# Altium schematic sheet laser_power_sw.SchDoc (continued)

## Library data of the parts
C28 C47 C49 C68 = Capacitor_3: 2kV, X7R, 10%; Manufacturer: YAGEO; Manufacturer_Part_Number: CC1206KKX7RDBB102; Component Kind: Standard; Library Name: HiPin_Library_AD.IntLib
C29 C30 C32 C33 C41 C42 C44 C45 C50 C51 C55 C56 C62 C63 C65 C66 C81 = Capacitor_3: 50V, X7R, 20%; Internal_Part_Number: MCD-00008; Manufacturer: muRata; Manufacturer_Part_Number: GRM32ER71H106KA12L; Component Kind: Standard; Library Name: HiPin_Library_AD.IntLib; Value: 10uF
C31 C43 C46 C52 C64 C67 = Capacitor_2: 50V, X7R , 10%; Internal_Part_Number: MCD-00014; Manufacturer: muRata; Manufacturer_Part_Number: GRM188R71H103KA01D; Component Kind: Standard; ComponentLink1Description: Datasheet; ComponentLink1URL: http://tunmcdsvn.tun.st.com/Component_Datasheet_Library/Trunk/Capacitors/Yageo_Multilayer_capacitor_X5R_series_100pF_to_100uF; Library Name: HiPin_Library_AD.IntLib; Value: 10nF
C34 C35 = 10uF: 35V,15Ω@100kHz; BOM_Manufacturer: AVX; BOM_Manufacturer Part: TAJA334K035RNJ; nameAlias: Capacitance; Component Kind: Standard; Library Name: HiPin_Library_AD.IntLib
C36 C38 C57 C59 = HV1V337M1010PZ: 330uF, 50V, 20%; Manufacturer: HONOR(荣誉); Add into BOM: yes; L: 10mm; Rated Voltage: 35V; Component Kind: Standard; Convert to PCB: yes; Datasheet: https://atta.szlcsc.com/upload/public/pdf/source/20240802/61683F5000C7CFED5606ABBE3CFBE0F5.pdf; Device: HV1V337M1010PZ; JLCPCB Part Class: Extended Part; LCSC Part Name: 330uF ±20% 35V; Library Name: HiPin_Library_AD.IntLib; Manufacturer Part: HV1V337M1010PZ; Operating Temperature: -55℃~+105℃; Supplier: LCSC; Supplier Footprint: SMD,D10xL10mm; Supplier Part: C5246558; Symbol: HV1V337M1010PZ; Tolerance: ±20%; φD: 10mm
C37 C39 C40 C58 C60 C61 = Capacitor_3: 50V, X7R, 10%; Manufacturer: muRata; Manufacturer_Part_Number: GRM31CR61H106KA12L; Component Kind: Standard; Library Name: HiPin_Library_AD.IntLib; Value: 10uF
C48 C69 C78 = Capacitor_2: 50V, X5R, 10%; Internal_Part_Number: MCD-00014; Manufacturer: muRata; Manufacturer_Part_Number: GRM21BR61E226ME44L; Value: 22uF; Component Kind: Standard; ComponentLink1Description: Datasheet; ComponentLink1URL: http://tunmcdsvn.tun.st.com/Component_Datasheet_Library/Trunk/Capacitors/Yageo_Multilayer_capacitor_X5R_series_100pF_to_100uF; Library Name: HiPin_Library_AD.IntLib
C53 = Capacitor_1: 25V, X7R, 10%; Manufacturer: muRata; Manufacturer_Part_Number: GCM188R71E105KA64D; Component Kind: Standard; ComponentLink1Description: Yageo_Capacitor_X5R-series.pdf; ComponentLink1URL: http://tunmcdsvn.tun.st.com/Component_Datasheet_Library/Trunk/Capacitors/Yageo_Multilayer_capacitor_X5R_series_100pF_to_100uF; Library Name: HiPin_Library_AD.IntLib; Package: C0603
C54 = 10uF: TA 0.8ohm; Manufacturer: AVX; Manufacturer Part: TAJA475K016RNJ; Component Kind: Standard; Library Name: HiPin_Library_AD.IntLib
C70 = 10uF: TA 0.8ohm; BOM_Manufacturer: AVX; BOM_Manufacturer Part: TAJA334K035RNJTAJA334K035RNJ; nameAlias: Capacitance; BOM_JLCPCB Part Class: Basic Part; BOM_Supplier: LCSC; BOM_Supplier Part: C7171; Component Kind: Standard; Library Name: HiPin_Library_AD.IntLib; spicePre: C; spiceSymbolName: TAJA106K016RNJ
C71 = 100uF: 6.3mm*7.7mm,16V; BOM_Manufacturer: HONOR(荣誉); BOM_Manufacturer Part: GVE1C471M0607; Contributor: LCSC; nameAlias: Capacitance; BOM_JLCPCB Part Class: Extended Part; Component Kind: Standard; Library Name: HiPin_Library_AD.IntLib; spicePre: C; spiceSymbolName: UWT1V101MCL1GS
C72 = TAJD107M020RNJ: ±20%,额定电压20V, 500mΩ@100kHz; Manufacturer: Kyocera AVX; Add into BOM: yes; Equivalent Series Resistance(ESR): 500m��@100kHz; Rated Voltage: 20V; Tolerance: ��20%; Value: 100uF; Component Kind: Standard; Convert to PCB: yes; Datasheet: https://atta.szlcsc.com/upload/public/pdf/source/20180326/C99373_20A9870CE26650D026AD36BBE6313154.pdf; Device: TAJD107M020RNJ; JLCPCB Part Class: Extended Part; LCSC Part Name: 100uF ��20% 20V; Library Name: HiPin_Library_AD.IntLib; Manufacturer Part: TAJD107M020RNJ; Operating Temperature: -55��~+125��; Supplier: LCSC; Supplier Footprint: CASE-D_7343; Supplier Part: C99373; Symbol: TAJD107M020RNJ
C73 = Capacitor_1: 16V, X5R, 10%; Internal_Part_Number: MCD-00009; Manufacturer: muRata; Component Kind: Standard; ComponentLink1Description: Yageo_Capacitor_X5R-series.pdf; ComponentLink1URL: http://tunmcdsvn.tun.st.com/Component_Datasheet_Library/Trunk/Capacitors/Yageo_Multilayer_capacitor_X5R_series_100pF_to_100uF; Library Name: HiPin_Library_AD.IntLib; Package: C0603
C74 C77 C87 C92 C99 = Capacitor_1: 16V, X5R, 10%; Internal_Part_Number: MCD-00009; Manufacturer: muRata; Manufacturer_Part_Number: GRM188R61E105KA12D; Component Kind: Standard; ComponentLink1Description: Yageo_Capacitor_X5R-series.pdf; ComponentLink1URL: http://tunmcdsvn.tun.st.com/Component_Datasheet_Library/Trunk/Capacitors/Yageo_Multilayer_capacitor_X5R_series_100pF_to_100uF; Library Name: HiPin_Library_AD.IntLib; Package: C0603; Value: 1uF
C75 C76 = Capacitor: 50V, 10%, X7R; Internal_Part_Number: MCD-00003; Manufacturer: muRata; Manufacturer_Part_Number: GRM155R71H104KE14D; Component Kind: Standard; ComponentLink1Description: Datasheet; ComponentLink1URL: http://tunmcdsvn.tun.st.com/Component_Datasheet_Library/Trunk/Capacitors/Yageo_Capacitor_NP0_16V-to-50V; Library Name: HiPin_Library_AD.IntLib; Package: C0402
  C75: Value: 100nF
C79 = C352S475K9SC000: CBB电容，22.5mm; Manufacturer: faratronic(厦门法拉); Add into BOM: yes; Tolerance: ±10%; Voltage Rated: 450V; Component Kind: Standard; Convert to PCB: yes; Datasheet: https://atta.szlcsc.com/upload/public/pdf/source/20220818/525217545BC089B5EDAE0BB9513219DD.pdf; Device: C352S475K9SC000; JLCPCB Part Class: Extended Part; LCSC Part Name: 4.7uF ±10% 450V; Library Name: HiPin_Library_AD.IntLib; Manufacturer Part: C352S475K9SC000; PCB3D: CAP-TH_L26.5-W11.0-H23.0-P22.5; Supplier: LCSC; Supplier Footprint: 插件,P=22.5mm; Supplier Part: C4944910; Symbol: C352S475K9SC000; Value: 4.7uF
C80 C83 C96-C98 C107 = Capacitor_3: 25V, X7R, 10%; Internal_Part_Number: MCD-00008; Manufacturer: muRata; Manufacturer_Part_Number: GRM31CR71E475KA88L; Component Kind: Standard; Library Name: HiPin_Library_AD.IntLib
C82 = Capacitor_3: 50V, C0G, 1%; Internal_Part_Number: MCD-00008; Manufacturer: muRata; Manufacturer_Part_Number: GRM31C5C1H104FA01L; Component Kind: Standard; Library Name: HiPin_Library_AD.IntLib
C84-C86 C90 C91 C100 C101 C103-C106 = Capacitor_1: 50V, X7R, 10%; Manufacturer: muRata; Manufacturer_Part_Number: GRM188R71H104KA93D; Component Kind: Standard; ComponentLink1Description: Yageo_Capacitor_X5R-series.pdf; ComponentLink1URL: http://tunmcdsvn.tun.st.com/Component_Datasheet_Library/Trunk/Capacitors/Yageo_Multilayer_capacitor_X5R_series_100pF_to_100uF; Library Name: HiPin_Library_AD.IntLib; Package: C0603
C88 = Capacitor_2: 50V, X5R, 10%; Internal_Part_Number: MCD-00014; Manufacturer: muRata; Manufacturer_Part_Number: GRM21BR61E226ME44L; Component Kind: Standard; ComponentLink1Description: Datasheet; ComponentLink1URL: http://tunmcdsvn.tun.st.com/Component_Datasheet_Library/Trunk/Capacitors/Yageo_Multilayer_capacitor_X5R_series_100pF_to_100uF; Library Name: HiPin_Library_AD.IntLib
C89 C102 = Capacitor_1: 50V,C0G, 5%; Manufacturer: muRata; Manufacturer_Part_Number: GRM1885C1H101JA01D; Component Kind: Standard; ComponentLink1Description: Yageo_Capacitor_X5R-series.pdf; ComponentLink1URL: http://tunmcdsvn.tun.st.com/Component_Datasheet_Library/Trunk/Capacitors/Yageo_Multilayer_capacitor_X5R_series_100pF_to_100uF; Library Name: HiPin_Library_AD.IntLib; Package: C0603
C93 = 10uF: TA 0.8ohm; BOM_Manufacturer: AVX; BOM_Manufacturer Part: TAJA106K016RNJ; nameAlias: Capacitance; BOM_JLCPCB Part Class: Basic Part; BOM_Supplier: LCSC; BOM_Supplier Part: C7171; Component Kind: Standard; Library Name: HiPin_Library_AD.IntLib; spicePre: C; spiceSymbolName: TAJA106K016RNJ
C94 C95 = Capacitor_1: 50V,C0G, 5%; Manufacturer: muRata; Manufacturer_Part_Number: GCM1885C1H221JA16D; Component Kind: Standard; ComponentLink1Description: Yageo_Capacitor_X5R-series.pdf; ComponentLink1URL: http://tunmcdsvn.tun.st.com/Component_Datasheet_Library/Trunk/Capacitors/Yageo_Multilayer_capacitor_X5R_series_100pF_to_100uF; Library Name: HiPin_Library_AD.IntLib; Package: C0603
CN1 CN2 = BUFU-1650-01: Number of Leg: 16; Screw Hole Size: M5; Component Kind: Standard; LCSC Part Name: PCB焊接端子抽牙M6 六脚 14.5*14.5*15mm T1.5 镀雾锡; Library Name: HiPin_Library_AD.IntLib; PCB3D: TH_6P-L14.5-W14.5-P6.25; Supplier Footprint: 插件; Supplier Part: C19724526
D4 = SS34_C8678: BOM_Manufacturer: MDD; BOM_JLCPCB Part Class: Basic Part; BOM_Manufacturer Part: SS34; BOM_Supplier: LCSC; BOM_Supplier Part: C8678; Component Kind: Standard; Library Name: HiPin_Library_AD.IntLib; spicePre: D; spiceSymbolName: SS34_C8678
D5 = DFLS160-7: Manufacturer: DIODES(美台); Add into BOM: yes; Forward Voltage (Vf@If): 500mV@1A; Rectified Current: 1A; Reverse Voltage (Vr): 60V; Component Kind: Standard; Convert to PCB: yes; Datasheet: https://atta.szlcsc.com/upload/public/pdf/source/20181114/C177023_80F0A2460033305602CFDDCB3101FB4E.pdf; Device: DFLS160-7; JLCPCB Part Class: Extended Part; LCSC Part Name: 电压:60V 电流:1A; Library Name: HiPin_Library_AD.IntLib; Manufacturer Part: DFLS160-7; PCB3D: POWERDI-123_L2.8-W1.8-H1.0-LS3.7; Supplier: LCSC; Supplier Footprint: Power-DI-123; Supplier Part: C177023; Symbol: DFLS160-7
D6 = SMBJ18A/TR13: Manufacturer: Brightking(��ҫ����); Add into BOM: yes; Breakdown Voltage: 20V; Maximum Clamping Voltage: 29.2V; Peak Pulse Current (Ipp)@10/1000us: 20.6A; Peak Pulse Power Dissipation (Ppp)@10/1000us: 600W; polarity: ����; Reverse Leakage Current (Ir): 1uA; Reverse Stand-Off Voltage (Vrwm): 18V; Symbol: SMBJ18A-C78412; Type: TVS; Component Kind: Standard; Convert to PCB: yes; Datasheet: https://atta.szlcsc.com/upload/public/pdf/source/20181127/C309995_EB3DFB9F9AEE5D2146ECD9FA5992A402.pdf; Device: SMBJ18A/TR13; JLCPCB Part Class: Extended Part; LCSC Part Name: SMBJ18A/TR13; Library Name: HiPin_Library_AD.IntLib; Manufacturer Part: SMBJ18A/TR13; Supplier: LCSC; Supplier Footprint: SMB(DO-214AA); Supplier Part: C78412
D7 = 1N4148W-C84367: BOM_Manufacturer: MDD; BOM_JLCPCB Part Class: Extended Part; BOM_Manufacturer Part: 1N4148W; BOM_Supplier: LCSC; BOM_Supplier Part: C84367; Component Kind: Standard; Library Name: HiPin_Library_AD.IntLib; spicePre: D; spiceSymbolName: 1N4148W-C84367
D8 = SMAJ6.8CA_C406209: 极性  双向  反向截止电压  18V  钳位电压  29.2V  峰值脉冲电流  13.7A@10/1000; Manufacturer: HJC; Add into BOM: yes; Reverse Stand-Off Voltage (Vrwm): 6.8V; Type: TVS; Component Kind: Standard; Convert to PCB: yes; Library Name: HiPin_Library_AD.IntLib; Manufacturer Part: SMAJ18CA; Supplier Footprint: SMA(DO-214AC)
F2 F3 = RES: 耐压: 30V  最大电流: 40A  保持电流(Ih): 500mA  跳闸电流: 1A; Value: 10K; Component Kind: Standard; Library Name: HiPin_Library_AD.IntLib
FB1 FB2 = BLM31PG601SN1L: Manufacturer: Sunlord(顺络); Add into BOM: yes; Circuits: 1; Current Rating: 1.5A; DC Resistance: 80mΩ; Impedance @ Frequency: 600Ω@100MHz; Tolerance: ±25%; Component Kind: Standard; Convert to PCB: yes; Datasheet: https://atta.szlcsc.com/upload/public/pdf/source/20180326/C88997_FE7208E239BA92CF7577D85CF2703D30.pdf; Device: BLM31PG601SN1L; JLCPCB Part Class: Extended Part; LCSC Part Name: 磁珠 阻抗38Ω@100MHz 5A; Library Name: HiPin_Library_AD.IntLib; Manufacturer Part: PZ3216D380-5R0TF; Supplier: LCSC; Supplier Footprint: 1206; Supplier Part: C16902; Symbol: BLM31PG601SN1L
L3 L4 = XRUU9.8-1.5mH-V: Manufacturer: XR(祥如); Add into BOM: yes; Inductance @ Frequency: 1.5mH@1kHz; Number of Lines: 2; Component Kind: Standard; Convert to PCB: yes; Datasheet: https://atta.szlcsc.com/upload/public/pdf/source/20240926/D8705A132F62F7D546575D85F6052ABD.pdf; Device: XRUU9.8-1.5mH-V; JLCPCB Part Class: Extended Part; LCSC Part Name: UU9.8 1.5mH@1KHz; Library Name: HiPin_Library_AD.IntLib; Manufacturer Part: XRUU9.8-1.5mH-V; PCB3D: FILTER-TH_4P-L16.5-W11.5_YDUU9.8-603L0201; Supplier: LCSC; Supplier Footprint: 插件; Supplier Part: C5358150; Symbol: XRUU9.8-1.5MH-V; Voltage Withstand: -
L5 = MCD-00047: SMD Chip ferrite bead, 220R@100MHz +/-25%,2.2A, 50mohm; Manufacturer: Sunlord; Manufacturer_Part_Number: UPZ1608U221-2R2TF; Database Table Name: MCD_PARTS$; Component Kind: Standard; ComponentLink1Description: Datasheet; ComponentLink1URL: http://tunmcdsvn.tun.st.com/Component_Datasheet_Library/Trunk/Inductors/TaiTech_Bead_FCM1608_series; Internal_Part_Number: MCD-00047; Library Name: MCD_PARTS_database.DbLib
L6 L7 = MCD-00047: 阻抗@频率220Ω@100MHz,误差±25%,直流电阻50mΩ,额定电流2.2A; Manufacturer: TDK; Part_Type: Inductor; Database Table Name: MCD_PARTS$; Provided_By: SUBCO; Component Kind: Standard; ComponentLink1Description: Datasheet; ComponentLink1URL: http://tunmcdsvn.tun.st.com/Component_Datasheet_Library/Trunk/Inductors/TaiTech_Bead_FCM1608_series; ECCN_EU: n/a; ECCN_US: n/a; Internal_Part_Number: MCD-00047; Library Name: MCD_PARTS_database.DbLib; Manufacturer_Part_Number: MPZ1608S221ATD25; Status: Released
LD3 = LED_green: LED, Green, 0603; Internal_Part_Number: MCD-00050; Manufacturer: EVERLIGHT; Manufacturer_Part_Number: 19-213SYGC/S530-E2/TR8; Part_Type: Display; ECCN_EU: NEC; ECCN_US: EAR99; Provided_By: SUBCO; Component Kind: Standard; ComponentLink1Description: Datasheet; ComponentLink1URL: http://tunmcdsvn.tun.st.com/Component_Datasheet_Library/Trunk/Display/Everlight_SMD-LED-GREEN_19-213SYGC-S530-XX-TR8-v3.doc; Library Name: mb1367.IntLib; Package: LED 0603; Status: Released
Q3 = CJMN3010: Nmos，DFN6(2X2); Manufacturer: CJ(江苏长电/长晶); Add into BOM: yes; Current - Continuous Drain(Id): 10A; Drain to Source Voltage: 30V; Gate Charge(Qg): 25nC@5V; Gate Threshold Voltage (Vgs(th)): 2.5V@250uA; Input Capacitance(Ciss): 2.65nF; Output Capacitance(Coss): 320pF; Pd - Power Dissipation: 2.5W; RDS(on): 16mΩ@4.5V; Reverse Transfer Capacitance (Crss@Vds): 290pF; Type: N沟道; Component Kind: Standard; Convert to PCB: yes; Datasheet: https://item.szlcsc.com/datasheet/CJMN3010/20524476.html; Device: CJMN3010; JLCPCB Part Class: Extended Part; LCSC Part Name: 耐压:30V 电流:10A; Library Name: HiPin_Library_AD.IntLib; Manufacturer Part: CJMN3010; Operating Temperature: -55℃~+150℃; PCB3D: DFNWB-6_L2.0-W2.0-H0.8-P0.65-BL-EP_CJM1206; Supplier: LCSC; Supplier Footprint: DFNWB2x2-6L; Supplier Part: C19267752; Symbol: CJMN3010
Q4 Q5 = 9013-SOT23: 晶体管类型: NPN  集电极电流(Ic): 600mA  集射极击穿电压(Vceo): 40V  耗散功率(Pd): 225mW; Alternate_Manufacturer: ON SEMICONDUCTOR; Alternate_Manufacturer_Part_Number: MMBT2222LT1G / MMBT2222ALT1G / MMBT2222ALT3G; Internal_Part_Number: MCD-00073; Manufacturer_Part_Number: BSR14; ECCN_EU: NEC; ECCN_US: EAR99; Provided_By: SUBCO; Component Kind: Standard; ComponentLink1Description: Datasheet; ComponentLink1URL: http://tunmcdsvn.tun.st.com/Component_Datasheet_Library/Trunk/IC/NXP_Transistor_BSR14; Library Name: HiPin_Library_AD.IntLib; Manufacturer: ON SEMICONDUCTOR; Package: SOT23; Part_Type: IC; Status: Released
Q6 Q7 = IRFP4768PBF: 漏源电压(Vdss)  250V  连续漏极电流(Id)  110A  导通电阻(RDS(on))  26mΩ@10V; Manufacturer: Littelfuse/IXYS; Component Kind: Standard; Library Name: HiPin_Library_AD.IntLib; Manufacturer_Part_Number: IXFH110N25T; PCB3D: TO-247AC-3_L15.8-W5.0-H20.5-P5.46
Q8 = MOSFET-N: N沟道,60V,0.115A,7Ω@10V; Manufactu: CJ江苏长电; ManufacturerNumber: 2N7002; Component Kind: Standard; Library Name: HiPin_Library_AD.IntLib; Package: SOT-23; SuppliersPartNumber: C8545
R19 R21 R25 R27 R30 R37-R39 R41 R45 R49-R55 R57 R58 R60-R66 R76-R83 R93 R94 = RES: 1%; Value: 10K; Component Kind: Standard; Library Name: HiPin_Library_AD.IntLib
R20 R23 R24 R26 R28 R29 R32 R36 R44 R46 R48 R59 = RES: 1%; Component Kind: Standard; Library Name: HiPin_Library_AD.IntLib
  R20 R23 R24 R26 R28 R29 R32 R36 R44 R46 R48: Value: 10K
R22 = RES: 1%, 250mW; Manufacturer: UNI-ROYAL(厚声); Manufacturer_Part_Number: 1206W4F0000T5E; Component Kind: Standard; Library Name: HiPin_Library_AD.IntLib
R33 = PA2512FKE070R005E: 1W; Manufacturer: YAGEO(国巨); Manufacturer Part: RC2512FK-0710RL; Component Kind: Standard; Library Name: HiPin_Library_AD.IntLib; PCB3D: RES-SMD_L6.4-W3.2_C500733
R35 = RES: 1%,125mW,±100ppm/℃; Manufacturer: YAGEO(国巨); Manufacturer_Part_Number: RC0805FR-07499RL; Component Kind: Standard; Library Name: HiPin_Library_AD.IntLib
R40 = CR-M10W-10Ω 5% P_C2766049: Manufacturer: CCO(千志电子); Add into BOM: yes; Overload Voltage (Max): 1kV; Power(Watts): 10W; Symbol: CR-M10W-10ΩJ; Tolerance: ±5%; Component Kind: Standard; Convert to PCB: yes; Datasheet: https://atta.szlcsc.com/upload/public/pdf/source/20180316/C5EEBFF70AF0400F010F42806D5D504C.pdf; Device: CR-M10W-10Ω 5% P_C2766049; JLCPCB Part Class: Extended Part; LCSC Part Name: 水泥电阻 10Ω ±5% 10W; Library Name: HiPin_Library_AD.IntLib; Manufacturer Part: CR-M10W-10Ω 5% P; Operating Temperature Range: -55℃~+250℃; PCB3D: RES-TH_L13.0-W9.5-P4.50-D0.7; Supplier: LCSC; Supplier Footprint: 插件,P=4.5mm; Supplier Part: C2766049; Type: 水泥电阻; Value: 10Ω
R42 = 3224W-1-103E: 可调电阻; Manufacturer: BOURNS; Add into BOM: yes; Power(Watts): 250mW; Temperature Coefficient: ±100ppm/℃; Tolerance: ±10%; Turns: 12; Component Kind: Standard; Convert to PCB: yes; Datasheet: https://atta.szlcsc.com/upload/public/pdf/source/20160830/1472551532733.pdf; Device: 3224W-1-103E; JLCPCB Part Class: Extended Part; LCSC Part Name: 10kΩ ±10%; Library Name: HiPin_Library_AD.IntLib; Manufacturer Part: 3224W-1-103E; PCB3D: RES-ADJ-SMD_3224W; Supplier: LCSC; Supplier Footprint: SMD-3P,4.8x3.5mm; Supplier Part: C81348; Symbol: 3224W-1-103E; Value: 10kΩ
R43 = EWWR0008J270RT9: 10W; Manufacturer: RESI(开步睿思); Add into BOM: yes; Power(Watts): 8W; Temperature Coefficient: ±250ppm/℃; Tolerance: ±5%; Component Kind: Standard; Convert to PCB: yes; Datasheet: https://atta.szlcsc.com/upload/public/pdf/source/20230201/1B3D2D7E42CE9D2055BF95E570A62506.pdf; Device: EWWR0008J270RT9; JLCPCB Part Class: Extended Part; LCSC Part Name: 绕线电阻 270Ω ±5% 8W; Library Name: HiPin_Library_AD.IntLib; Manufacturer Part: EWWR0008J270RT9; Operating Temperature Range: -55℃~+155℃; Overload Voltage (Max): -; PCB3D: RES-TH_BD9.0-L31.5-P37.00-D0.8; Supplier: LCSC; Supplier Footprint: 插件,D9xL31.5mm; Supplier Part: C349035; Symbol: EWWR0008J270RT9; Type: 绕线电阻; Value: 270Ω
R47 = 3224W-1-103E: 可调电阻; Manufacturer: BOURNS; Manufacturer Part: 3224W-1-104E; Add into BOM: yes; Power(Watts): 250mW; Temperature Coefficient: ±100ppm/℃; Tolerance: ±10%; Turns: 12; Value: 10kΩ; Component Kind: Standard; Convert to PCB: yes; Datasheet: https://atta.szlcsc.com/upload/public/pdf/source/20160830/1472551532733.pdf; Device: 3224W-1-103E; JLCPCB Part Class: Extended Part; LCSC Part Name: 10kΩ ±10%; Library Name: HiPin_Library_AD.IntLib; PCB3D: RES-ADJ-SMD_3224W; Supplier: LCSC; Supplier Footprint: SMD-3P,4.8x3.5mm; Supplier Part: C81348; Symbol: 3224W-1-103E
R73 R74 = SEWF5930D2L00P9: Manufacturer: RESI(开步睿思); Add into BOM: yes; Mounting Sytle: 贴片; Power(Watts): 8W; Temperature Coefficient: ±25ppm/℃; Tolerance: ±0.5%; Component Kind: Standard; Convert to PCB: yes; Datasheet: https://atta.szlcsc.com/upload/public/pdf/source/20231024/C97852864F9228193D97966695F02FC0.pdf; Device: SEWF5930D2L00P9; JLCPCB Part Class: Extended Part; LCSC Part Name: 2mΩ ±0.5% 8W; Library Name: HiPin_Library_AD.IntLib; Manufacturer Part: SEWF5930D2L00P9; PCB3D: R5930; Supplier: LCSC; Supplier Footprint: 5930; Supplier Part: C19192940; Symbol: SEWF5930D2L00P9; Type: 采样电阻; Value: 2mΩ
U6 U12 = URA2415S-6WR3_C5357415: Manufacturer: MORNSUN(金升阳); Add into BOM: yes; Conversion Efficiency: 83%; Input Voltage(DC): 9V~36V; Insulated Voltage: 1.5kV; Output Channel: 2; Output Current (Max): 200mA; Output Power: 6W; Output Voltage: 15V; Component Kind: Standard; Convert to PCB: yes; Device: URA2415S-6WR3_C5357415; JLCPCB Part Class: Extended Part; LCSC Part Name: URA2415S-6WR3; Library Name: HiPin_Library_AD.IntLib; Manufacturer Part: URA2415S-6WR3; PCB3D: PWRM-TH_7P-L22.0-W9.5-P2.54-WRE1205S-3WR2; Supplier: LCSC; Supplier Footprint: SIP-7; Supplier Part: C5357415; Symbol: URA2415S-6WR3_C5357415
U7 U15 = L78M05CDT-TR: LDO  output:DC5V; BOM_Manufacturer: STMicroelectronics; BOM_JLCPCB Part Class: Extended Part; BOM_Manufacturer Part: L78M05CDT-TR; BOM_Supplier: LCSC; BOM_Supplier Part: C55509; Component Kind: Standard; Library Name: HiPin_Library_AD.IntLib; spicePre: U; spiceSymbolName: L78M05CDT-TR
U8 U13 = TPS7A3901DSCR: Manufacturer: TI(德州仪器); Add into BOM: yes; Maximum Input Voltage: 33V; Number of Outputs: 2; Output Current: 150mA; Output Polarity: 正极和负极; Output Type: 可调; Output Voltage: 1.2V~30V；0V~30V; Power Supply Rejection Ratio (PSRR): 56dB@(100KHz); Component Kind: Standard; Convert to PCB: yes; Datasheet: https://www.ti.com/cn/lit/gpn/tps7a39; Device: TPS7A3901DSCR; JLCPCB Part Class: Extended Part; LCSC Part Name: 150mA、33V、低噪声、高 PSRR、双通道、正负电压范围、低压降稳压器; Library Name: HiPin_Library_AD.IntLib; Manufacturer Part: TPS7A3901DSCR; Operating Temperature: -40℃~+125℃@(Tj); PCB3D: WSON-10_L3.0-W3.0-H1.0-P0.50; Supplier: LCSC; Supplier Footprint: WSON-10-EP(3x3); Supplier Part: C2685819; Symbol: TPS7A3901DSCR
U9 = DF63M-2P-3.96DSA: Manufacturer: HRS(广濑); Add into BOM: yes; Component Kind: Standard; Convert to PCB: yes; Datasheet: https://atta.szlcsc.com/upload/public/pdf/source/20221205/6AC71532B9B9566170A63CE16B2C46ED.pdf; Device: DF63M-2P-3.96DSA; JLCPCB Part Class: Extended Part; LCSC Part Name: DF63M-2P-3.96DSA; Library Name: HiPin_Library_AD.IntLib; Manufacturer Part: DF63M-2P-3.96DSA; Supplier: LCSC; Supplier Footprint: 插件,P=3.96mm; Supplier Part: C5254350; Symbol: DF63M-2P-3.96DSA
U10 U14 = CYA0630-10UH: Manufacturer: SHOU HAN(首韩); Add into BOM: yes; DC Resistance (DCR): 71mΩ; Name: 10uH; Rated Current: 4A; Tolerance: ±20%; Value: 10uH; Component Kind: Standard; Convert to PCB: yes; Datasheet: https://atta.szlcsc.com/upload/public/pdf/source/20221010/B55E8796F05F85A38044F3826C14EFDD.pdf; Device: CYA0630-10UH; JLCPCB Part Class: Extended Part; LCSC Part Name: 10uH ±20%; Library Name: HiPin_Library_AD.IntLib; Manufacturer Part: CYA0630-10UH; PCB3D: IND-SMD_L7.2-W6.6-H3.0_GPSR07X0; Supplier: LCSC; Supplier Footprint: SMD,6.6x7.2mm; Supplier Part: C5189958; Symbol: CYA0630-10UH
U11 = REF3025AIDBZR: Manufacturer: TI(德州仪器); Add into BOM: yes; Input Voltage: 2.55V~5.5V; Noise - 1/10Hz to 10Hz: 28uVp-p; Noise - 10Hz to 10kHz: 80uVrms; Output Current: 25mA; Output Type: 固定; Reference Type: 串联; Supply Current: 50uA; Temperature Coefficient: 75ppm/℃; Tolerance: ±0.2%; Component Kind: Standard; Convert to PCB: yes; Datasheet: https://atta.szlcsc.com/upload/public/pdf/source/20130225/1457706593773.pdf; Device: REF3025AIDBZR; JLCPCB Part Class: Extended Part; LCSC Part Name: 串联 25mA; Library Name: HiPin_Library_AD.IntLib; Manufacturer Part: REF3025AIDBZR; Name: REF3025AIDBZR; Operating Temperature: -40℃~+125℃@(Ta); PCB3D: SOT-23-3L_L2.9-W1.6-H1.1-LS2.8-P0.95; Supplier: LCSC; Supplier Footprint: SOT-23; Supplier Part: C11334; Symbol: REF3025AIDBZR
U16 = EL817S1(C)(TU)-F: Manufacturer: EVERLIGHT(亿光); Add into BOM: yes; Collector-Emitter Saturation Voltage (Vce(sat)@Ic,IF): 100mV@1mA,20mA; Fall time: 18us@2mA,100Ω; Forward Voltage: 1.2V; Input voltage type: DC; Isolation Voltage(rms): 5kV; Load Voltage: 35V; Number of Outputs: 1; Output Current: 50mA; Output Type: 光电三极管; Reverse Voltage: 6V; Component Kind: Standard; Convert to PCB: yes; Datasheet: https://atta.szlcsc.com/upload/public/pdf/source/20181026/C323798_FBCE14484708FE0807B9A090D624C4F0.pdf; Device: EL817S1(C)(TU)-F; JLCPCB Part Class: Basic Part; LCSC Part Name: DC输入 隔离电压(rms):5000V; Library Name: HiPin_Library_AD.IntLib; Manufacturer Part: EL817S1(C)(TU)-F; Operating Temperature: -55℃~+110℃; Rise time: 18us@2mA,100Ω; Supplier: LCSC; Supplier Footprint: SMD-4P; Supplier Part: C106900; Symbol: EL817S1(C)(TU)-F
U17 = TPS3711DDCR: 36V欠压检测; Manufacturer: TI(德州仪器); Add into BOM: yes; ChipType: 电压检测器; Number of Voltages Monitored: 1; Output Type: 开漏; Component Kind: Standard; Convert to PCB: yes; Datasheet: https://www.ti.com/cn/lit/gpn/tps3711; Device: TPS3711DDCR; JLCPCB Part Class: Extended Part; LCSC Part Name: TPS3711DDCR; Library Name: HiPin_Library_AD.IntLib; Manufacturer Part: TPS3711DDCR; Operating Temperature: -40℃~+125℃@(TJ); PCB3D: TSOT-23-6_L2.9-W1.6-H1.1-LS2.8-P0.95; Supplier: LCSC; Supplier Footprint: TSOT-23-6; Supplier Part: C2877180; Symbol: TPS3711DDCR
U18 = SN74LVC1G123DCTR: Manufacturer: TI(德州仪器); Add into BOM: yes; Input Type: 施密特触发器; Logic Family: 74LVC; Logic Gate Type: 单稳态; Number Of Channels: 1; Propagation Delay: 18.5ns; Sink Current: 32mA; Supply Voltage: 1.65V~5.5V; Component Kind: Standard; Convert to PCB: yes; Datasheet: https://www.ti.com/cn/lit/gpn/sn74lvc1g123; Device: SN74LVC1G123DCTR; JLCPCB Part Class: Extended Part; LCSC Part Name: SN74LVC1G123DCTR; Library Name: HiPin_Library_AD.IntLib; Manufacturer Part: SN74LVC1G123DCTR; OperatingTemperature: -40℃~+125℃; PCB3D: SM8_L3.2-W2.9-H1.3-LS4.3-P0.65; Source Current: 32mA; Supplier: LCSC; Supplier Footprint: SM-8; Supplier Part: C123302; Symbol: SN74LVC1G123DCTR
U19 = OPA182IDR: 36V、5MHz、低噪声、零漂移、多路复用器友好型精密运算放大器; Manufacturer: TI(德州仪器); -3db Bandwidth(G=1): 3.6MHz; Add into BOM: yes; Current - Input Bias(Ib): 50pA; Gain Bandwidth Product(GBP): 5MHz; Number of Circuits: 单路; Slew Rate(SR): 10V/us; Voltage - Input Offset(Vos): 450nV; Component Kind: Standard; Convert to PCB: yes; Datasheet: https://www.ti.com/cn/lit/gpn/opa2182; Device: OPA182IDR; JLCPCB Part Class: Extended Part; LCSC Part Name: OPA182IDR; Library Name: HiPin_Library_AD.IntLib; Manufacturer Part: OPA182IDR; Operating Temperature: -40℃~+125℃; Output Type: -; PCB3D: SOIC-8_L4.9-W3.9-H1.7-LS6.0-P1.27; Rail to Rail: -; Supplier: LCSC; Supplier Footprint: SOIC-8; Supplier Part: C4371168; Symbol: OPA182IDR
U20 U22 = MMBT3906_C111114: Manufacturer: SHIKUES(时科); Add into BOM: yes; Collector Current (Ic): 200mA; Collector-Emitter Breakdown Voltage (Vceo): 40V; Power Dissipation (Pd): 350mW; Transistor Type: PNP; Component Kind: Standard; Convert to PCB: yes; Datasheet: https://atta.szlcsc.com/upload/public/pdf/source/20170721/C111114_1500619262784834013.pdf; Device: MMBT3906_C111114; JLCPCB Part Class: Extended Part; LCSC Part Name: PNP 40V 200mA; Library Name: HiPin_Library_AD.IntLib; Manufacturer Part: MMBT3906; Name: MMBT3906; PCB3D: SOT-23-3L_L2.9-W1.6-H1.1-LS2.8-P0.95; Supplier: LCSC; Supplier Footprint: SOT-23; Supplier Part: C111114; Symbol: MMBT3906_C111114
U21 = TL081: Manufacturer: Gcore(扬州国芯); Add into BOM: yes; Component Kind: Standard; Convert to PCB: yes; Datasheet: https://atta.szlcsc.com/upload/public/pdf/source/20230919/4B17152F4A0E7D0B787868E80B807D7A.pdf; Device: TL081; JLCPCB Part Class: Extended Part; LCSC Part Name: TL081; Library Name: HiPin_Library_AD.IntLib; Manufacturer Part: TL081; PCB3D: SOP-8_L4.9-W3.9-P1.27-LS6.0-BL-1; Supplier: LCSC; Supplier Footprint: SOP-8; Supplier Part: C18184970; Symbol: TL081
U23 = SN74LVC1G66DCKR: 模拟开关 单刀单掷（常开）; Manufacturer: TI(德州仪器); Add into BOM: yes; Number of Channels: 1; On-State Resistance (Max): 10Ω; Supply Voltage: 1.65V~5.5V; Switch Circuit: 单刀单掷(SPST)-常开; Turn-off time: 5ns; Turn-on time: 4.2ns; Component Kind: Standard; Convert to PCB: yes; Datasheet: https://www.ti.com/cn/lit/gpn/sn74lvc1g66; Device: SN74LVC1G66DCKR; JLCPCB Part Class: Extended Part; LCSC Part Name: SN74LVC1G66 单双边模拟开关; Library Name: HiPin_Library_AD.IntLib; Manufacturer Part: SN74LVC1G66DCKR; PCB3D: SC-70-5_L2.0-W1.3-H1.0-P0.65; Supplier: LCSC; Supplier Footprint: SC-70-5; Supplier Part: C113518; Symbol: SN74LVC1G66DCKR
U24 = OPA2192IDR: Manufacturer: TI(德州仪器); Add into BOM: yes; Current - Input Bias(Ib): 5pA; Gain Bandwidth Product(GBP): 10MHz; Number of Circuits: 双路; Output Current: 65mA; Rail to Rail: 轨到轨输入输出; Slew Rate(SR): 20V/us; Supply Current: 1mA; -3db Bandwidth(G=1): -; Common Mode Rejection Ratio (CMRR): -; Component Kind: Standard; Convert to PCB: yes; Datasheet: https://www.ti.com/cn/lit/gpn/opa2192; Device: OPA2192IDR; Input Offset Voltage Drift (Vos TC): -; JLCPCB Part Class: Extended Part; LCSC Part Name: OPA2192IDR; Library Name: HiPin_Library_AD.IntLib; Manufacturer Part: OPA2192IDR; Operating Temperature: -40℃~+125℃; Output Type: -; PCB3D: SOIC-8_L4.9-W3.9-H1.7-LS6.0-P1.27; Supplier: LCSC; Supplier Footprint: SOIC-8; Supplier Part: C110074; Supply Voltage: -; Symbol: OPA2192IDR; Voltage - Input Offset(Vos): -
U25 = AD5541ABRMZ: Manufacturer: ADI(亚德诺); Add into BOM: yes; Integral nonlinearity: ±0.5LSB; Interface: QSPI；SPI；DSP；MICROWIRE; Number of Channels: 1; Output Type: 电压-非缓冲; Resolution: 16; Settling Time: 1us; Supply Voltage: 2.7V~5.5V; Component Kind: Standard; Convert to PCB: yes; Datasheet: https://atta.szlcsc.com/upload/public/pdf/source/20210609/C578210_16BB8A1AF4CFE195DFFA25901FDC5864.pdf; Device: AD5541ABRMZ; JLCPCB Part Class: Extended Part; LCSC Part Name: 2.7 V 至 5.5 V，串行输入，电压输出，未缓冲 16 位 DAC; Library Name: HiPin_Library_AD.IntLib; Manufacturer Part: AD5541ABRMZ; Operating Temperature: -40℃~+125℃; PCB3D: MSOP-10_L3.0-W3.0-H1.1-LS5.0-P0.50; Supplier: LCSC; Supplier Footprint: MSOP-10; Supplier Part: C578210; Symbol: AD5541ABRMZ
U26 = CA-IS3760LN: Manufacturer: Chipanalog(川土微); Add into BOM: yes; CMTI(kV/us): 150kV/us; Data Rate(Max): 150Mbps; Integrated Power Supply: 否; Isolation Voltage(Vrms): 3750; Number of Forward Channels: 6; Number of Reverse Channels: 0; Rating: 工业级; Component Kind: Standard; Convert to PCB: yes; Datasheet: https://atta.szlcsc.com/upload/public/pdf/source/20200518/C528657_47D007DF1698635F6E7523677F3A3784.pdf; Device: CA-IS3760LN; JLCPCB Part Class: Extended Part; LCSC Part Name: CA-IS376x 高速六通道数字隔离器; Library Name: HiPin_Library_AD.IntLib; Manufacturer Part: CA-IS3760LN; Operating Temperature: -40℃~125℃; PCB3D: SOIC-16_L9.9-W3.9-H1.8-LS6.0-P1.27; Supplier: LCSC; Supplier Footprint: SOIC-16; Supplier Part: C2887448; Symbol: CA-IS3760LN
U27 U28 = 35*12*25: Manufacturer: XSD(鑫顺达); Add into BOM: yes; ProductSize: 35*12*25MM; SurfaceTreatment: 本色洗白; Symbol: 35*12*25 梅花散热板; TextureOfMaterial: 6063-T5铝; Colour: 白色; Component Kind: Standard; Convert to PCB: yes; Datasheet: https://atta.szlcsc.com/upload/public/pdf/source/20230628/5C5A1893962425FD3C6549533A741452.pdf; density: -; Device: 35*12*25; Flame retardant: -; hardness: -; JLCPCB Part Class: Extended Part; LCSC Part Name: 35*12*25 梅花散热片; Library Name: HiPin_Library_AD.IntLib; Manufacturer Part: 35*12*25; NumberOfHoles: -; NumberOfNails: -; NumberOfTeeth: -; PCB3D: HEATSINK-TH_L34.2-W12.5-P25.40; Shape: -; specifications: -; SpringBuckle: -; Supplier: LCSC; Supplier Footprint: -; Supplier Part: C4663; Thermal conductivity: -; type: -; working temperature: -
